# Altium schematic sheet IO_ctrl.SchDoc(IO_ctrl)
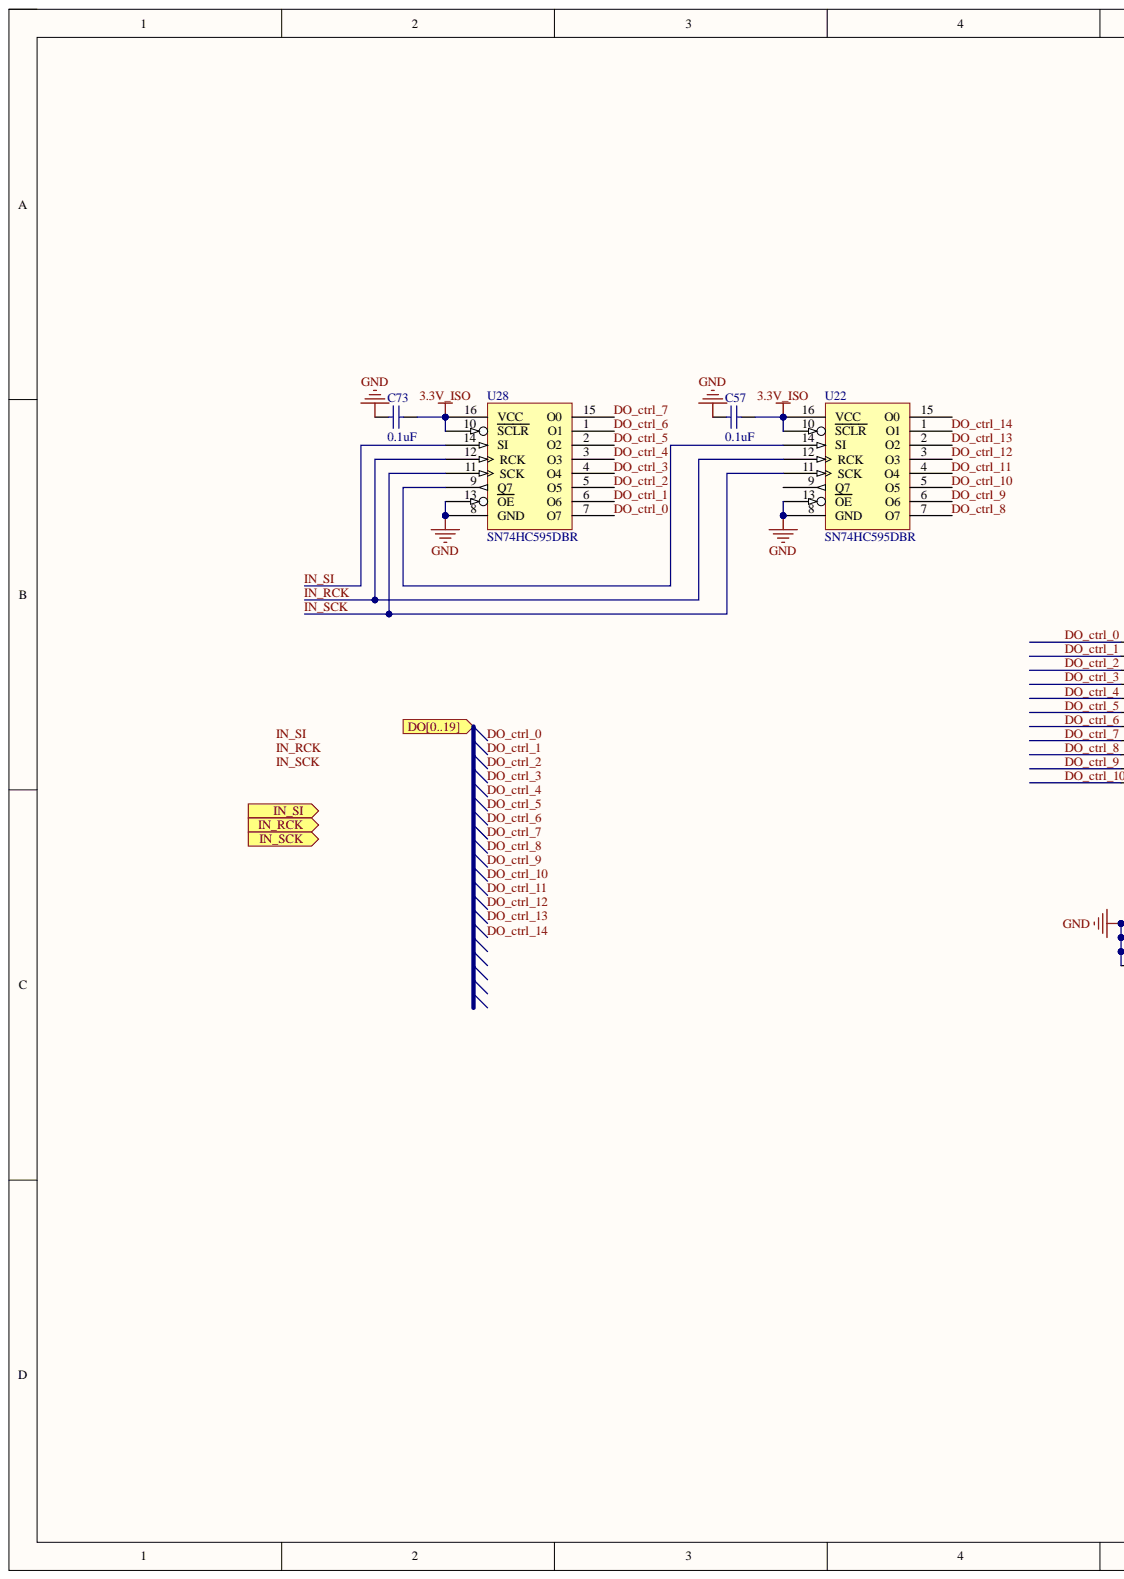
[diagram p0: IO_ctrl.SchDoc, left]
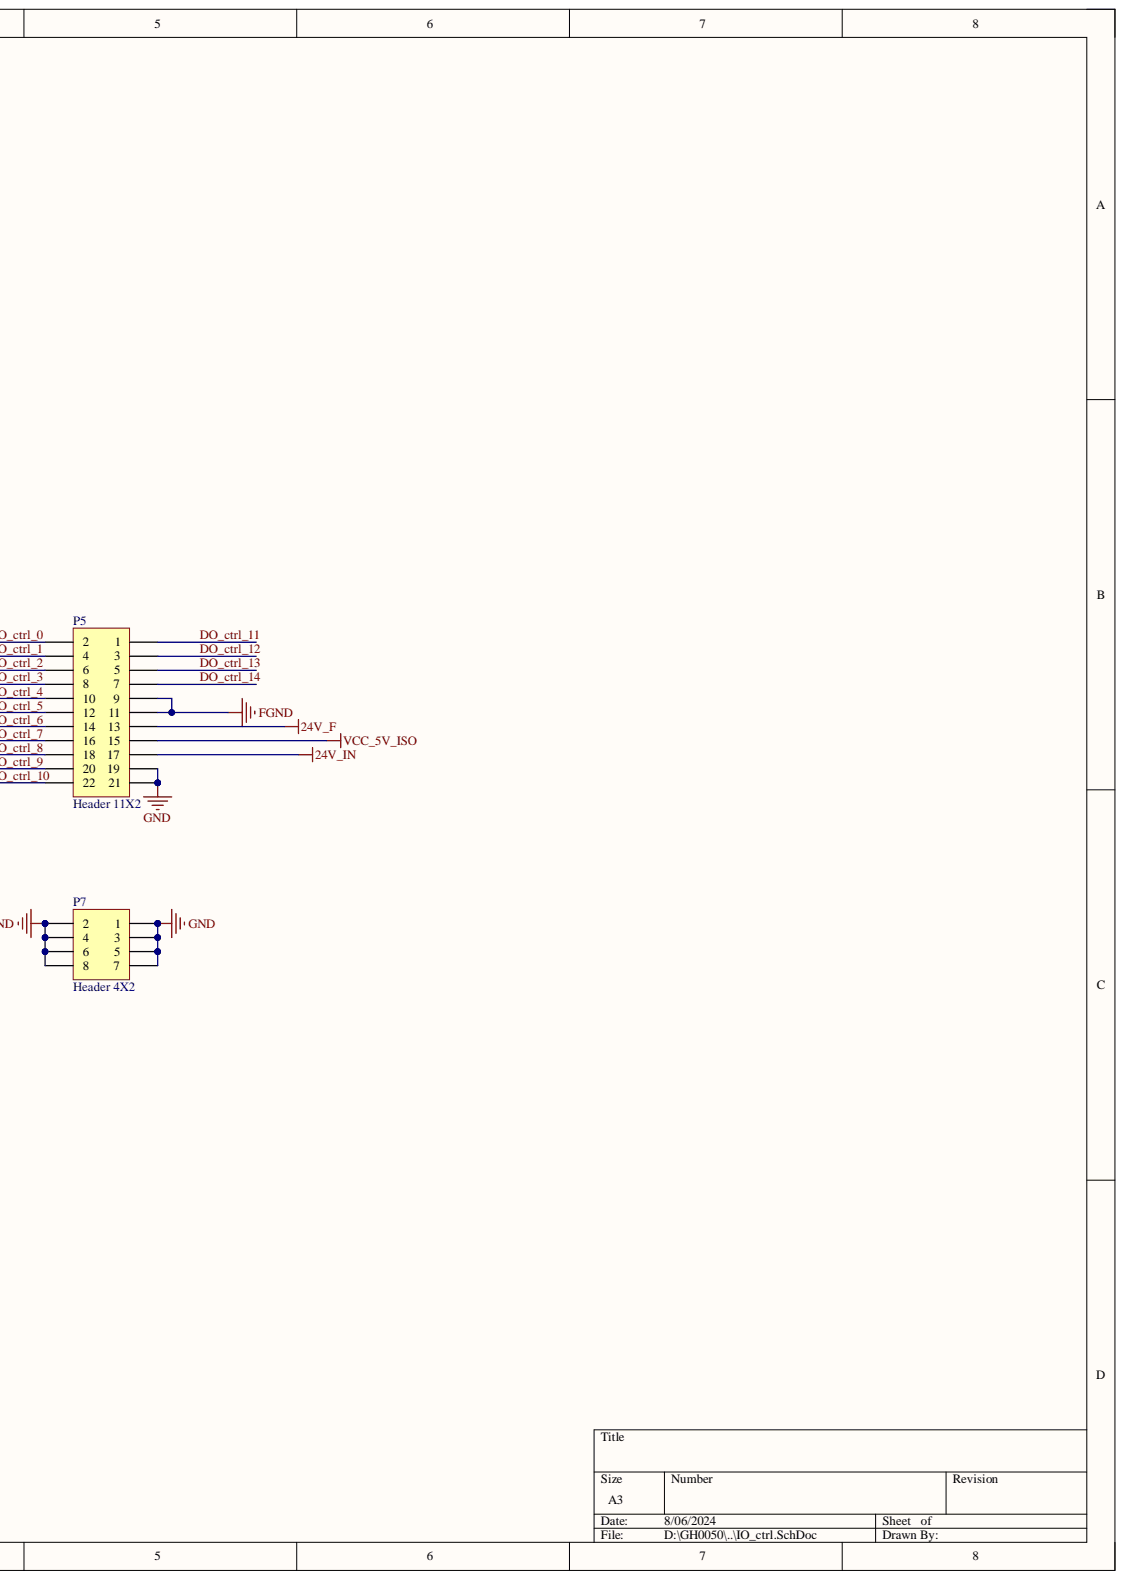
[diagram p1: IO_ctrl.SchDoc, right]

## Parts
C57  0.1uF  [0603C]
C73  0.1uF  [0603C]
P5  Header 11X2  [HDR2X11]
P7  Header 4X2  [HDR2X4]
U22  SN74HC595DBR  [SSOP16]
U28  SN74HC595DBR  [SSOP16]

## Ports
DO0, DO1, DO2, DO3, DO4, DO5, DO6, DO7, DO8, DO9, DO10, DO11, DO12, DO13, DO14, DO15, DO16, DO17, DO18, DO19, DO[0..19], IN_RCK, IN_SCK, IN_SI

## Nets
3.3V_ISO: C57.1 C73.1 U22.10(SCLR) U22.16(VCC) U28.10(SCLR) U28.16(VCC)
24V_F: P5.13
24V_IN: P5.17
FGND: P5.9 P5.11
GND: C57.2 C73.2 P5.19 P5.21 P7.1 P7.2 P7.3 P7.4 P7.5 P7.6 P7.7 P7.8 U22.8(GND) U22.13(OE) U28.8(GND) U28.13(OE)
VCC_5V_ISO: P5.15
DO_ctrl_0: P5.2 U28.7(O7)
DO_ctrl_1: P5.4 U28.6(O6)
DO_ctrl_2: P5.6 U28.5(O5)
DO_ctrl_3: P5.8 U28.4(O4)
DO_ctrl_4: P5.10 U28.3(O3)
DO_ctrl_5: P5.12 U28.2(O2)
DO_ctrl_6: P5.14 U28.1(O1)
DO_ctrl_7: P5.16 U28.15(O0)
DO_ctrl_8: P5.18 U22.7(O7)
DO_ctrl_9: P5.20 U22.6(O6)
DO_ctrl_10: P5.22 U22.5(O5)
DO_ctrl_11: P5.1 U22.4(O4)
DO_ctrl_12: P5.3 U22.3(O3)
DO_ctrl_13: P5.5 U22.2(O2)
DO_ctrl_14: P5.7 U22.1(O1)
IN_RCK: U22.12(RCK) U28.12(RCK)
IN_SCK: U22.11(SCK) U28.11(SCK)
IN_SI: U28.14(SI)
NetU22_14: U22.14(SI) U28.9(Q7)
N00003_0: ; port DO[0..19]
N00003_1: ; port DO[0..19]
N00003_2: ; port DO[0..19]
N00003_3: ; port DO[0..19]
N00003_4: ; port DO[0..19]
N00003_5: ; port DO[0..19]
N00003_6: ; port DO[0..19]
N00003_7: ; port DO[0..19]
N00003_8: ; port DO[0..19]
N00003_9: ; port DO[0..19]
N00003_10: ; port DO[0..19]
N00003_11: ; port DO[0..19]
N00003_12: ; port DO[0..19]
N00003_13: ; port DO[0..19]
N00003_14: ; port DO[0..19]
N00003_15: ; port DO[0..19]
N00003_16: ; port DO[0..19]
N00003_17: ; port DO[0..19]
N00003_18: ; port DO[0..19]
N00003_19: ; port DO[0..19]
N00050: ; port IN_RCK
N00052: ; port IN_SCK
N00054: ; port IN_SI

Not connected: U22 9(Q7) 15(O0)

## Library data of the parts
C57 C73 = Cap: Capacitor; Component Kind: Standard; LatestRevisionDate: 17-Jul-2002; LatestRevisionNote: Re-released for DXP Platform.; Library Name: Miscellaneous Devices.IntLib; PackageDescription: Radial Cap, Thru-Hole; 2 Leads; 0.3 in Pin Spacing; PackageReference: RAD-0.3; Published: 8-Jun-2000; Signal Integrity: Cap; Simulation: CAP; Value: 0.1uF; Publisher: Altium Limited
P5 = Header 11X2: Header, 11-Pin, Dual row; Component Kind: Standard; LatestRevisionDate: 17-Jul-2002; LatestRevisionNote: Re-released for DXP Platform.; Library Name: Miscellaneous Connectors.IntLib; Signal Integrity: Connector; Publisher: Altium Limited
P7 = Header 4X2: Header, 4-Pin, Dual row; Component Kind: Standard; LatestRevisionDate: 17-Jul-2002; LatestRevisionNote: Re-released for DXP Platform.; Library Name: Miscellaneous Connectors.IntLib; Signal Integrity: Connector; Publisher: Altium Limited
U22 U28 = 74HC595: Component Kind: Standard; Library Name: DI16.SchLib
